# Altium schematic sheet POS5V.schdoc
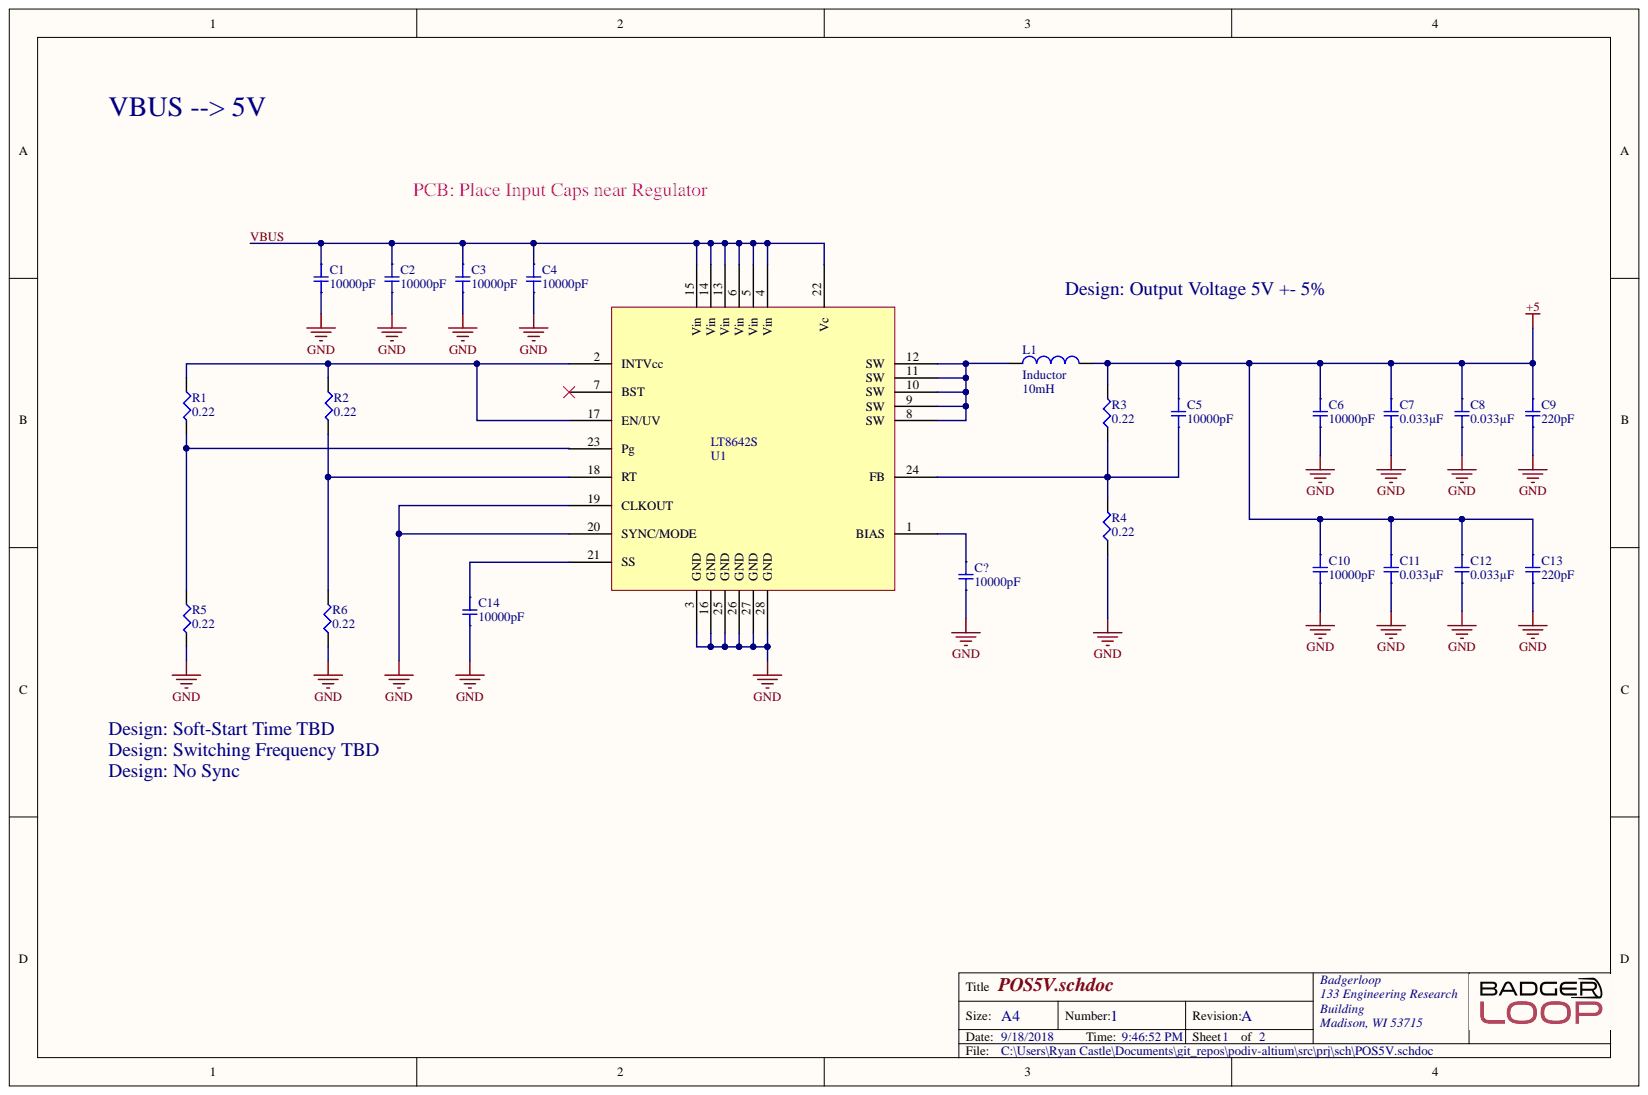
[diagram p0: POS5V.schdoc]

## Parts
C1  10000pF  [SMD-0805C]
C2  10000pF  [SMD-0805C]
C3  10000pF  [SMD-0805C]
C4  10000pF  [SMD-0805C]
C5  10000pF  [SMD-0805C]
C6  10000pF  [SMD-0805C]
C7  0.033µF  [SMD-1206C]
C8  0.033µF  [SMD-1206C]
C9  220pF  [SMD-0402C]
C10  10000pF  [SMD-0805C]
C11  0.033µF  [SMD-1206C]
C12  0.033µF  [SMD-1206C]
C13  220pF  [SMD-0402C]
C14  10000pF  [SMD-0805C]
C?  10000pF  [SMD-0805C]
L1  Inductor  value 10mH  [0402-A]
R1  0.22  [SMD-0402-RES]
R2  0.22  [SMD-0402-RES]
R3  0.22  [SMD-0402-RES]
R4  0.22  [SMD-0402-RES]
R5  0.22  [SMD-0402-RES]
R6  0.22  [SMD-0402-RES]
U1  LT8642S

## Nets
+5: C5.1 C6.1 C7.1 C8.1 C9.1 C10.1 C11.1 C12.1 C13.1 L1.2 R3.2
GND: C1.2 C2.2 C3.2 C4.2 C6.2 C7.2 C8.2 C9.2 C10.2 C11.2 C12.2 C13.2 C14.2 C?.2 R4.1 R5.2 R6.1 U1.3(GND) U1.16(GND) U1.19(CLKOUT) U1.20(SYNC/MODE) U1.25(GND) U1.26(GND) U1.27(GND) U1.28(GND)
VBUS: C1.1 C2.1 C3.1 C4.1 U1.4(Vin) U1.5(Vin) U1.6(Vin) U1.13(Vin) U1.14(Vin) U1.15(Vin) U1.22(Vc)
NetC5_2: C5.2 R3.1 R4.2 U1.24(FB)
NetC14_1: C14.1 U1.21(SS)
NetC?_1: C?.1 U1.1(BIAS)
NetL1_1: L1.1 U1.8(SW) U1.9(SW) U1.10(SW) U1.11(SW) U1.12(SW)
NetR1_1: R1.1 R2.1 U1.2(INTVcc) U1.17(EN/UV)
NetR1_2: R1.2 R5.1 U1.23(Pg)
NetR2_2: R2.2 R6.2 U1.18(RT)

Not connected: U1 7(BST)

## Library data of the parts
C1-C6 C10 C14 C? = GC321AD72E103KX01D: CAP CER 10000PF 250V X7T 0805; Manufacturer: Murata Electronics North America; @ qty: 0; Minimum Quantity: 1; Tolerance: ±10%; Unit Price (USD): 0.3; Applications: Automotive; Component Kind: Standard; Datasheets: http://search.murata.co.jp/Ceramy/image/img/A01X/G101/ENG/GC321AD72E103KX01-01.pdf; Digi-Key Part Number: 490-13986-1-ND; Factory Stock: 0; Features: -; Height - Seated (Max): -; Image: //media.digikey.com/Renders/Murata%20Renders/0805(GC3)200x125.jpg; Lead Spacing: -; Lead Style: -; Library Name: capacitor.SchLib; Mounting Type: Surface Mount, MLCC; Operating Temperature: -55°C ~ 125°C; Package / Case: 0805 (2012 Metric); Packaging: Cut Tape (CT); Part Number: GC321AD72E103KX01D; Part Status: Active; Quantity Available: 4000; Ratings: AEC-Q200; Series: GC3; Size / Dimension: 0.079" L x 0.049" W (2.00mm x 1.25mm); Temperature Coefficient: X7T; Thickness (Max): 0.039" (1.00mm); Value: 10000pF; Voltage: 250V
C7 C8 C11 C12 = GC331AD72E333KX01D: CAP CER 0.033UF 250V X7T 1206; Manufacturer: Murata Electronics North America; @ qty: 0; Minimum Quantity: 1; Tolerance: ±10%; Unit Price (USD): 0.33; Applications: Automotive; Component Kind: Standard; Datasheets: http://search.murata.co.jp/Ceramy/image/img/A01X/G101/ENG/GC331AD72E333KX01-01.pdf; Digi-Key Part Number: 490-13989-1-ND; Factory Stock: 0; Features: -; Height - Seated (Max): -; Image: //media.digikey.com/Renders/Murata%20Renders/1206(GC3)320x160.jpg; Lead Spacing: -; Lead Style: -; Library Name: capacitor.SchLib; Mounting Type: Surface Mount, MLCC; Operating Temperature: -55°C ~ 125°C; Package / Case: 1206 (3216 Metric); Packaging: Cut Tape (CT); Part Number: GC331AD72E333KX01D; Part Status: Active; Quantity Available: 3999; Ratings: AEC-Q200; Series: GC3; Size / Dimension: 0.126" L x 0.063" W (3.20mm x 1.60mm); Temperature Coefficient: X7T; Thickness (Max): 0.039" (1.00mm); Value: 0.033µF; Voltage: 250V
C9 C13 = GCM1555C1H221JA16D: CAP CER 220PF 50V NP0 0402; Manufacturer: Murata Electronics North America; @ qty: 0; Minimum Quantity: 1; Temperature Coefficient: C0G, NP0; Tolerance: ±5%; Unit Price (USD): 0.1; Applications: Automotive; Component Kind: Standard; Datasheets: http://www.murata.com/~/media/webrenewal/support/library/catalog/products/capacitor/mlcc/c03e.ashx; Digi-Key Part Number: 490-4927-1-ND; Factory Stock: 0; Features: -; Height - Seated (Max): -; Image: //media.digikey.com/Renders/Murata%20Renders/ZRB-SERIES-0402.jpg; Lead Spacing: -; Lead Style: -; Library Name: capacitor.SchLib; Mounting Type: Surface Mount, MLCC; Operating Temperature: -55°C ~ 125°C; Package / Case: 0402 (1005 Metric); Packaging: Cut Tape (CT); Part Number: GCM1555C1H221JA16D; Part Status: Active; Quantity Available: 20733; Ratings: AEC-Q200; Series: GCM; Size / Dimension: 0.039" L x 0.020" W (1.00mm x 0.50mm); Thickness (Max): 0.022" (0.55mm); Value: 220pF; Voltage: 50V
L1 = Inductor: Code_IEC: 1005; Code_JEITA: 0402; Component Kind: Standard; Description: Inductor; LatestRevisionDate: 29-May-2009; LatestRevisionNote: IPC-7351 Footprint Added.; Library Name: Miscellaneous Devices.IntLib; PackageDescription: Chip Inductor; PackageReference: 0402-A; Published: 8-Jun-2000; Signal Integrity: Inductor; Simulation: INDUCTOR; Publisher: Altium Limited
R1-R6 = ERJ-2BQFR22X: RES SMD 0.22 OHM 1% 1/6W 0402; Manufacturer: Panasonic Electronic Components; @ qty: 1500; Factory Stock: 0; Minimum Quantity: 1; Power (Watts): 0.167W, 1/6W; Temperature Coefficient: ±250ppm/°C; Unit Price (USD): 0.10080; Component Kind: Standard; Composition: Thick Film; Datasheets: https://industrial.panasonic.com/cdbs/www-data/pdf/RDA0000/AOA0000C239.pdf; Digi-Key Part Number: P.22AKCT-ND; Failure Rate: -; Features: Automotive AEC-Q200, Current Sense; Height - Seated (Max): 0.016" (0.40mm); Image: //media.digikey.com/Photos/Panasonic%20Photos/ERJ-PA2-Series.jpg; Library Name: resistor.SchLib; Number of Terminations: 2; Operating Temperature: -55°C ~ 125°C; Package / Case: 0402 (1005 Metric); Packaging: Cut Tape (CT); Part Number: ERJ-2BQFR22X; Part Status: Active; Quantity Available: 14922; Series: ERJ; Size / Dimension: 0.039" L x 0.020" W (1.00mm x 0.50mm); Supplier Device Package: 0402; Tolerance: ±1%; Value: 0.22
U1 = LT8642S: 18V, 10A Synchronous  Step-Down Silent Switcher 2; Component Kind: Standard; Library Name: ic.SchLib
